# Altium schematic sheet RadioPi.SchDoc
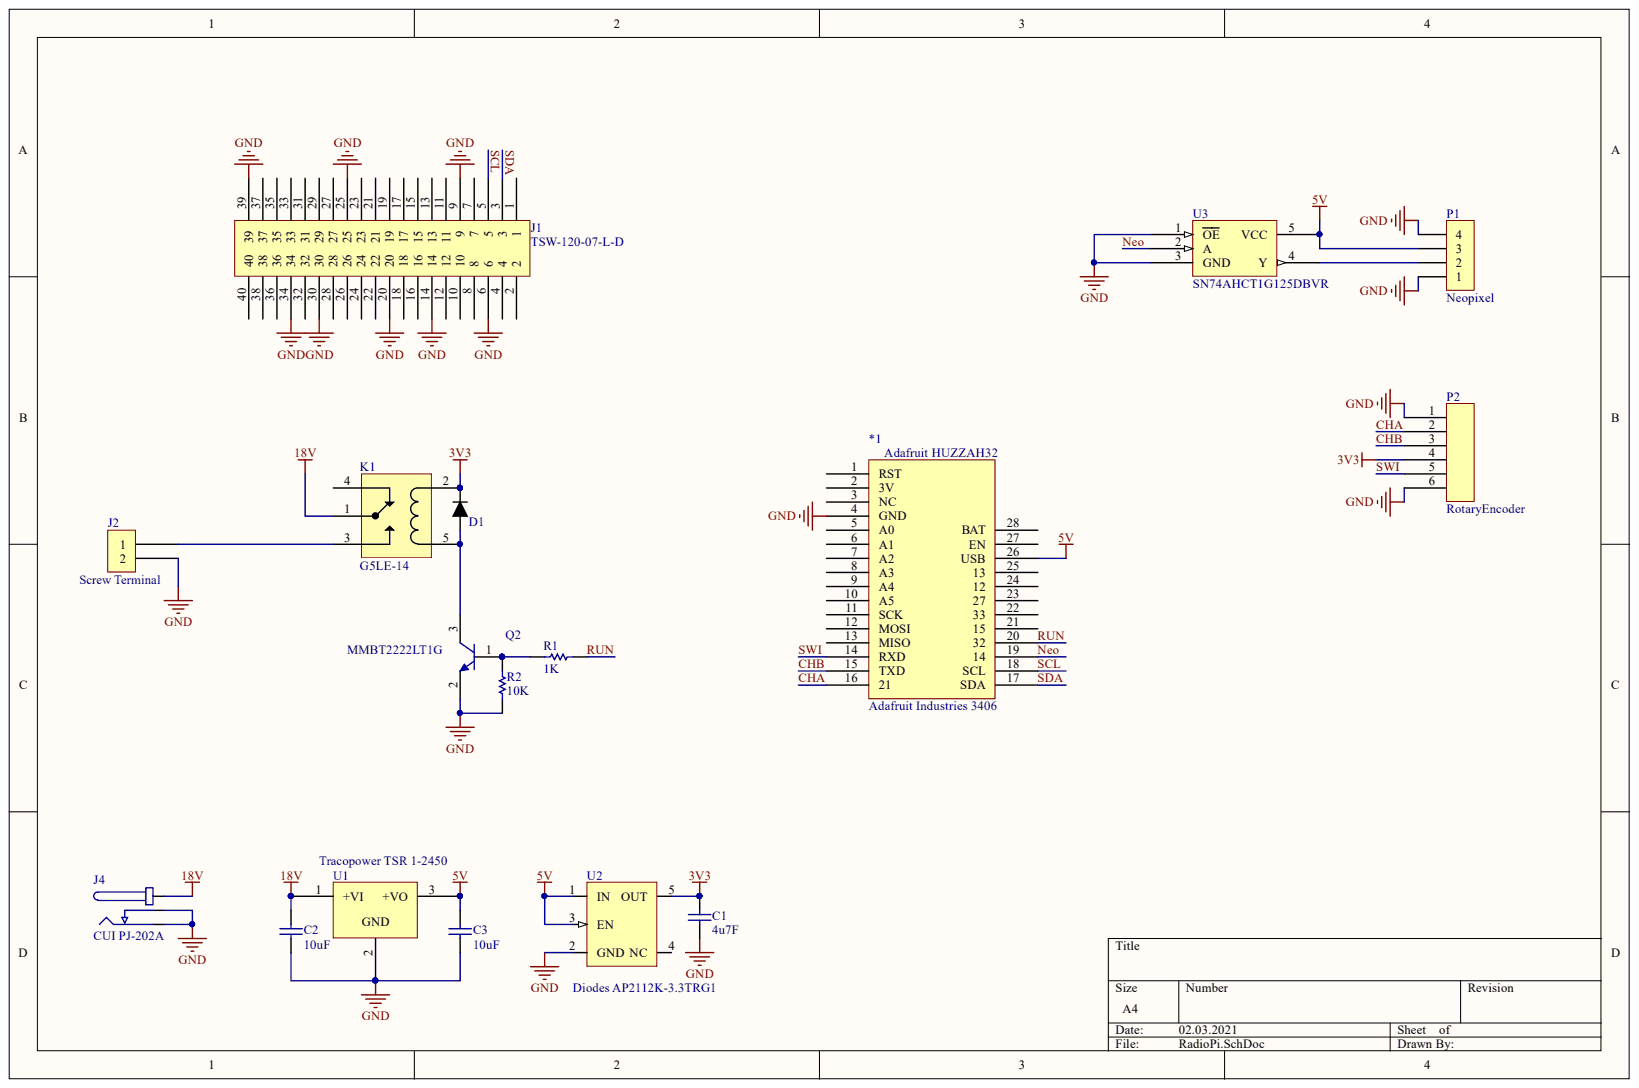
[diagram p0: RadioPi.SchDoc]

## Parts
*1  Adafruit Industries 3406  [PCB-96ppzt64ofni4v1sfnm0-1]
C1  4u7F  [CAPC2012X13N]
C2  10uF  [CAPC2012X13N]
C3  10uF  [CAPC2012X13N]
D1  Diodes Inc. 1N4148WS-7-F  [DIOD-1N4148WS-SOD-323-2]  (value not drawn)
J1  TSW-120-07-L-D  [PCB-uoggrudqvzngozweo305-1]
J2  Screw Terminal  [PCB-vjtdhx3m2ckd5s5erdrd-1]
J4  CUI PJ-202A  [PCB-9l1m8cs3gxaealq4dcxz-1]
K1  G5LE-14  [PCB-cgfzojg7j2d32jyez98u-1]
P1  Neopixel  [PCB-5sny9tk3fb02ft3l14e0-1]
P2  RotaryEncoder  [PCB-xpy7bts8fi64cenuh4kz-1]
Q2  MMBT2222LT1G  [ONSC-SOT-23-3-318-08_V]
R1  1K  [PCB-z9398susdmjz6r0b6hpk-1]
R2  10K  [PCB-z9398susdmjz6r0b6hpk-1]
U1  Tracopower TSR 1-2450  [PCB-4dryq0ktsvefsd6wsf1l-1]
U2  Diodes AP2112K-3.3TRG1  Manufacturer Part Number: AP2112K-3.3TRG1  [SOT23-5_D]
U3  SN74AHCT1G125DBVR  [MF05A_L]

## Nets
3V3: C1.2 D1.1 K1.2 P2.4 U2.5(OUT)
5V: *1.26(USB) C3.2 P1.3 U1.3 U2.1(IN) U2.3(EN) U3.5(VCC)
18V: C2.2 J4.1 K1.1 U1.1
GND: *1.4(GND) C1.1 C2.1 C3.1 J1.6 J1.9 J1.14 J1.20 J1.25 J1.30 J1.34 J1.39 J2.2 J4.2 J4.3 P1.1 P1.4 P2.1 P2.6 Q2.2 R2.1 U1.2 U2.2(GND) U3.1(OE) U3.3(GND)
CHA: *1.16 P2.2
CHB: *1.15(TXD) P2.3
NEO: *1.19 U3.2(A)
RUN: *1.20 R1.2
SCL: *1.18(SCL) J1.5
SDA: *1.17(SDA) J1.3
SWI: *1.14(RXD) P2.5
NetD1_2: D1.2 K1.5 Q2.3
NetJ2_1: J2.1 K1.3
NetP1_2: P1.2 U3.4(Y)
NetQ2_1: Q2.1 R1.1 R2.2

Not connected: *1 1(RST) 2(3V) 3(NC) 5(A0) 6(A1) 7(A2) 8(A3) 9(A4) 10(A5) 11(SCK) 12(MOSI) 13(MISO) 21 22 23 24 25 27(EN) 28(BAT); J1 1 2 4 7 8 10 11 12 13 15 16 17 18 19 21 22 23 24 26 27 28 29 31 32 33 35 36 37 38 40; K1 4; U2 4(NC)

## Library data of the parts
*1 = CMP-51769c9990b48eb1-16: Adafruit HUZZAH32 – ESP32 Feather Board; Component Kind: Standard; Library Name: CommunityVault
C1-C3 = CMP-ede9262fae2c322e-7: 0805 35 V 10 uF ±10% Tolerance X6S Multilayer Ceramic Capacitor; Component Kind: Standard; Library Name: CommunityVault
D1 = CMP-815266-15: DIODE SWITCHING 75V 0.15A SOD323; Component Kind: Standard; Library Name: CommunityVault
J1 = CMP-44f88df646179b3f-5: Conn Unshrouded Header HDR 40 POS 2.54mm Solder ST Thru-Hole; Gender: Male; Component Kind: Standard; Library Name: CommunityVault; OctopartID: 44f88df646179b3f; Octopart URL: http://octopart.com/tsw-120-07-l-d-samtec-272265
J2 = CMP-799bb091269ba65c-3: Conn; Term Blk; Screw; 2: 3.5 mm; 26-16 AWG; 10 A; 160 V; Solder; 2.5 kV; PA; Manufacturer Part Number: 1751248; Lead-Free Status: Lead Free; Number of Positions: 2; Component Kind: Standard; Insulation Material: Nylon; Library Name: CommunityVault; Mounting Style: Solder; OctopartID: 799bb091269ba65c; Octopart URL: http://octopart.com/1751248-phoenix+contact-31334; RoHS: Compliant
J4 = CMP-66b0afbe2377b977-6: 2.0 mm Center Pin, 2.5 A, Right Angle, Through Hole, Dc Power Jack Connector; Manufacturer Part Number: PJ-002A; Gender: Male; Lead-Free Status: Lead Free; Mounting Angle: 180.0 °; Shielding: No; Color: Black; Component Kind: Standard; Contact Plating: Nickel; Library Name: CommunityVault; Lifecycle Status: Active; Mounting Style: Through Hole; OctopartID: 66b0afbe2377b977; Octopart URL: http://octopart.com/pj-002a-cui-286881; Orientation: Horizontal; Packaging: Bulk; RoHS: Compliant; Size-Diameter: 0.0021 m
K1 = CMP-7f70b6190af7a0b7-3: Electromechanical Relay SPDT 8ADC/10AAC 3VDC Through Hole; Manufacturer Part Number: G5LE-14-DC3; Component Kind: Standard; Library Name: CommunityVault; Mounting Style: Through Hole; OctopartID: 7f70b6190af7a0b7; Octopart URL: https://octopart.com/g5le-14-dc3-omron-6508769; RoHS: Compliant
P1 = CMP-1127631-14: KK® 254 Breakaway Header, Vertical, 4 Circuits, Tin (Sn) Plating, Mating Pin Length 6.09mm; Current Rating: 4.00 A; Gender: Male; Glow Wire Compliant: Non-Compliant; Lead-Free Status: Lead Free; Number of Circuits: 4; Pin Pitch: 2.54 mm; Component Kind: Standard; Contact Material: Brass; Contact Plating: Tin; Halogen Free Status: Not Low Halogen; Housing Color: Black; Housing Material: Nylon; Library Name: CommunityVault; Lifecycle Status: Active; Manufacturer Part Number: 22-28-4040; Mounting Style: Through Hole, Vertical; Number of Contacts: 4; Number of Positions: 4; Number of Rows: 1; OctopartID: 3e2ef72025d4e1e9; Octopart URL: http://octopart.com/22-28-4040-molex-278172; Orientation: Vertical; Packaging: Bulk; RoHS: Compliant; Size-Height: 2.29 mm; Size-Length: 10.2 mm; Size-Width: 2.49 mm
P2 = CMP-b7717ee446b868b6-5: 2.54mm (.100") Pitch KK® Header, Breakaway, Vertical, 6 Circuits, Tin (Sn) Plating, Mating Pin Length 6.09mm (.240"); Component Kind: Standard; Library Name: CommunityVault
Q2 = CMP-7261029-3: General Purpose Transistor, NPN Silicon, 3-Pin SOT-23, Pb-Free, Tape and Reel; Current Rating: 0.6 A; Lead-Free Status: Lead Free; Power Dissipation: 0.225 W; Voltage Rating (DC): 30.0 V; Case/Package: SOT-23; Component Kind: Standard; Library Name: CommunityVault; Lifecycle Status: Active; Manufacturer Part Number: MMBT2222LT1G; Mounting Style: Surface Mount; Number of Pins: 3; OctopartID: 69cca0c33971b60b; Octopart Url: https://octopart.com/mmbt2222lt1g-on+semiconductor-357851; REACH SVHC Compliance: No SVHC; RoHS: Compliant
R1 R2 = CMP-19961812-7: @ RESISTOR,SMT,0805,1K OHM, +/-1%,+-100ppm,THICKFILM(100); Component Kind: Standard; Library Name: CommunityVault
U1 = CMP-ee6f27f8e1ca31a8-10: TRACOPOWER Switching Regulator; 6.5 - 36V dc Input; 5V Output; 1A; Component Kind: Standard; Library Name: CommunityVault
U2 = CMP-15095236-4: AP2112 Series 0.6 A 3.3 V Fixed Output SMT LDO Linear Regulator - SOT23-5; Lead-Free Status: Lead Free; Component Kind: Standard; Library Name: CommunityVault; Lifecycle Status: Not Listed by Manufacturer; OctopartID: 0ec2c6107f99d105; Octopart Url: http://octopart.com/ap2112k-3.3trg1-diodes+inc.-30954557; RoHS: Compliant; Seller Landing  Url: https://octopart-clicks.com/click/track?country=US&ak=8157f166&sig=01867c6&sid=459&ppid=30954557&vpid=95776131&ct=offers
U3 = CMP-127adb772effcdbd-1: Single Bus Buffer Gate With 3-State Output 5-SOT-23 -40 to 125; Quiescent Current: 1.00 µA (max); Component Kind: Standard; Library Name: CommunityVault
